# Altium schematic sheet cpu.SchDoc(cpu)
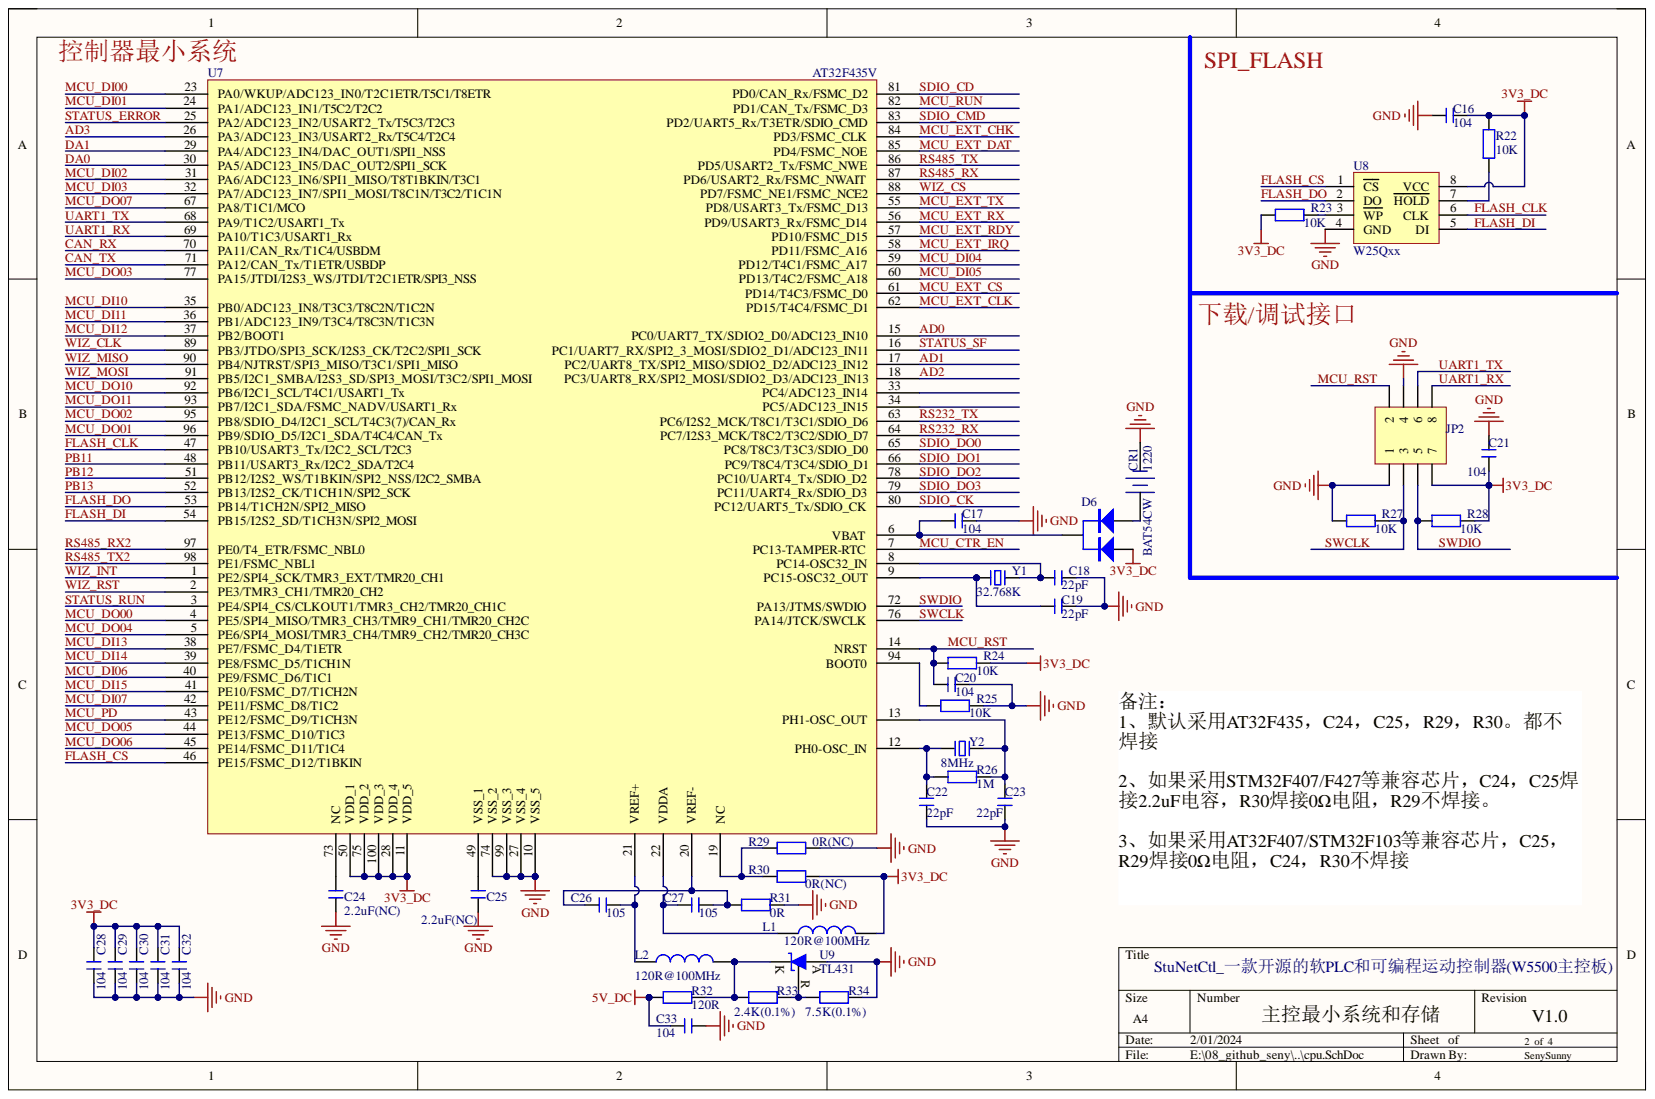
[diagram p0: cpu.SchDoc]

## Parts
C16  104  [C 0603]
C17  104  [C 0603]
C18  22pF  [C 0603]
C19  22pF  [C 0603]
C20  104  [C 0603]
C21  104  [C 0603]
C22  22pF  [C 0603]
C23  22pF  [C 0603]
C24  2.2uF(NC)  [C 0603]
C25  2.2uF(NC)  [C 0603]
C26  105  [C 0603]
C27  105  [C 0603]
C28  104  [C 0603]
C29  104  [C 0603]
C30  104  [C 0603]
C31  104  [C 0603]
C32  104  [C 0603]
C33  104  [C 0603]
CR1  1220  [CR1220_A]
D6  BAT54CW  [SOT23-3N]
JP2  Header 4X2  [HDR-4X2]  (value not drawn)
L1  120R@100MHz  [L 0603]
L2  120R@100MHz  [L 0603]
R22  10K  [R 0603]
R23  10K  [R 0603]
R24  10K  [R 0603]
R25  10K  [R 0603]
R26  1M  [R 0603]
R27  10K  [R 0603]
R28  10K  [R 0603]
R29  0R(NC)  [R 0603]
R30  0R(NC)  [R 0603]
R31  0R  [R 0603]
R32  120R  [R 0603]
R33  2.4K(0.1%)  [R 0603]
R34  7.5K(0.1%)  [R 0603]
U7  AT32F435V  [LQFP100 14X14_N]
U8  W25Qxx  [WSON-8_L6.0-W5.0-P1.27-BL-EP]
U9  TL431  [SOT23-3N]
Y1  32.768K  [XTAL-3215-2P]
Y2  8MHz  [XTAL-5032-2P]

## Nets
3V3_DC: C16.1 C21.2 C28.1 C29.1 C30.1 C31.1 C32.1 D6.1 JP2.7 L1.2 R22.1 R23.1 R24.1 R28.1 R30.2 U7.11(VDD_5) U7.28(VDD_4) U7.50(VDD_1) U7.75(VDD_2) U7.100(VDD_3) U8.8(VCC)
5V_DC: C33.2 R32.2
GND: C16.2 C17.1 C18.1 C19.1 C20.1 C21.1 C22.1 C23.1 C24.2 C25.2 C28.2 C29.2 C30.2 C31.2 C32.2 C33.1 CR1.2 JP2.1 JP2.4 R25.1 R27.2 R29.2 R31.1 R34.1 U7.10(VSS_5) U7.27(VSS_4) U7.74(VSS_2) U7.99(VSS_3) U8.4(GND) U9.3
AD0: U7.15(PC0/UART7_TX/SDIO2_D0/ADC123_IN10)
AD1: U7.17(PC2/UART8_TX/SPI2_MISO/SDIO2_D2/ADC123_IN12)
AD2: U7.18(PC3/UART8_RX/SPI2_MOSI/SDIO2_D3/ADC123_IN13)
AD3: U7.26(PA3/ADC123_IN3/USART2_Rx/T5C4/T2C4)
CAN_RX: U7.70(PA11/CAN_Rx/T1C4/USBDM)
CAN_TX: U7.71(PA12/CAN_Tx/T1ETR/USBDP)
DA0: U7.30(PA5/ADC123_IN5/DAC_OUT2/SPI1_SCK)
DA1: U7.29(PA4/ADC123_IN4/DAC_OUT1/SPI1_NSS)
FLASH_CLK: U7.47(PB10/USART3_Tx/I2C2_SCL/T2C3) U8.6(CLK)
FLASH_CS: U7.46(PE15/FSMC_D12/T1BKIN) U8.1(CS)
FLASH_DI: U7.54(PB15/I2S2_SD/T1CH3N/SPI2_MOSI) U8.5(DI)
FLASH_DO: U7.53(PB14/T1CH2N/SPI2_MISO) U8.2(DO)
MCU_CTR_EN: U7.7(PC13-TAMPER-RTC)
MCU_DI00: U7.23(PA0/WKUP/ADC123_IN0/T2C1ETR/T5C1/T8ETR)
MCU_DI01: U7.24(PA1/ADC123_IN1/T5C2/T2C2)
MCU_DI02: U7.31(PA6/ADC123_IN6/SPI1_MISO/T8T1BKIN/T3C1)
MCU_DI03: U7.32(PA7/ADC123_IN7/SPI1_MOSI/T8C1N/T3C2/T1C1N)
MCU_DI04: U7.59(PD12/T4C1/FSMC_A17)
MCU_DI05: U7.60(PD13/T4C2/FSMC_A18)
MCU_DI06: U7.40(PE9/FSMC_D6/T1C1)
MCU_DI07: U7.42(PE11/FSMC_D8/T1C2)
MCU_DI10: U7.35(PB0/ADC123_IN8/T3C3/T8C2N/T1C2N)
MCU_DI11: U7.36(PB1/ADC123_IN9/T3C4/T8C3N/T1C3N)
MCU_DI12: U7.37(PB2/BOOT1)
MCU_DI13: U7.38(PE7/FSMC_D4/T1ETR)
MCU_DI14: U7.39(PE8/FSMC_D5/T1CH1N)
MCU_DI15: U7.41(PE10/FSMC_D7/T1CH2N)
MCU_DO00: U7.4(PE5/SPI4_MISO/TMR3_CH3/TMR9_CH1/TMR20_CH2C)
MCU_DO01: U7.96(PB9/SDIO_D5/I2C1_SDA/T4C4/CAN_Tx)
MCU_DO02: U7.95(PB8/SDIO_D4/I2C1_SCL/T4C3(7)/CAN_Rx)
MCU_DO03: U7.77(PA15/JTDI/I2S3_WS/JTDI/T2C1ETR/SPI3_NSS)
MCU_DO04: U7.5(PE6/SPI4_MOSI/TMR3_CH4/TMR9_CH2/TMR20_CH3C)
MCU_DO05: U7.44(PE13/FSMC_D10/T1C3)
MCU_DO06: U7.45(PE14/FSMC_D11/T1C4)
MCU_DO07: U7.67(PA8/T1C1/MCO)
MCU_DO10: U7.92(PB6/I2C1_SCL/T4C1/USART1_Tx)
MCU_DO11: U7.93(PB7/I2C1_SDA/FSMC_NADV/USART1_Rx)
MCU_EXT_CHK: U7.84(PD3/FSMC_CLK)
MCU_EXT_CLK: U7.62(PD15/T4C4/FSMC_D1)
MCU_EXT_CS: U7.61(PD14/T4C3/FSMC_D0)
MCU_EXT_DAT: U7.85(PD4/FSMC_NOE)
MCU_EXT_IRQ: U7.58(PD11/FSMC_A16)
MCU_EXT_RDY: U7.57(PD10/FSMC_D15)
MCU_EXT_RX: U7.56(PD9/USART3_Rx/FSMC_D14)
MCU_EXT_TX: U7.55(PD8/USART3_Tx/FSMC_D13)
MCU_PD: U7.43(PE12/FSMC_D9/T1CH3N)
MCU_RST: C20.2 JP2.2 R24.2 U7.14(NRST)
MCU_RUN: U7.82(PD1/CAN_Tx/FSMC_D3)
PB11: U7.48(PB11/USART3_Rx/I2C2_SDA/T2C4)
PB12: U7.51(PB12/I2S2_WS/T1BKIN/SPI2_NSS/I2C2_SMBA)
PB13: U7.52(PB13/I2S2_CK/T1CH1N/SPI2_SCK)
RS232_RX: U7.64(PC7/I2S3_MCK/T8C2/T3C2/SDIO_D7)
RS232_TX: U7.63(PC6/I2S2_MCK/T8C1/T3C1/SDIO_D6)
RS485_RX: U7.87(PD6/USART2_Rx/FSMC_NWAIT)
RS485_RX2: U7.97(PE0/T4_ETR/FSMC_NBL0)
RS485_TX: U7.86(PD5/USART2_Tx/FSMC_NWE)
RS485_TX2: U7.98(PE1/FSMC_NBL1)
SDIO_CD: U7.81(PD0/CAN_Rx/FSMC_D2)
SDIO_CK: U7.80(PC12/UART5_Tx/SDIO_CK)
SDIO_CMD: U7.83(PD2/UART5_Rx/T3ETR/SDIO_CMD)
SDIO_DO0: U7.65(PC8/T8C3/T3C3/SDIO_D0)
SDIO_DO1: U7.66(PC9/T8C4/T3C4/SDIO_D1)
SDIO_DO2: U7.78(PC10/UART4_Tx/SDIO_D2)
SDIO_DO3: U7.79(PC11/UART4_Rx/SDIO_D3)
STATUS_ERROR: U7.25(PA2/ADC123_IN2/USART2_Tx/T5C3/T2C3)
STATUS_RUN: U7.3(PE4/SPI4_CS/CLKOUT1/TMR3_CH2/TMR20_CH1C)
STATUS_SF: U7.16(PC1/UART7_RX/SPI2_3_MOSI/SDIO2_D1/ADC123_IN11)
SWCLK: JP2.3 R27.1 U7.76(PA14/JTCK/SWCLK)
SWDIO: JP2.5 R28.2 U7.72(PA13/JTMS/SWDIO)
UART1_RX: JP2.8 U7.69(PA10/T1C3/USART1_Rx)
UART1_TX: JP2.6 U7.68(PA9/T1C2/USART1_Tx)
WIZ_CLK: U7.89(PB3/JTDO/SPI3_SCK/I2S3_CK/T2C2/SPI1_SCK)
WIZ_CS: U7.88(PD7/FSMC_NE1/FSMC_NCE2)
WIZ_INT: U7.1(PE2/SPI4_SCK/TMR3_EXT/TMR20_CH1)
WIZ_MISO: U7.90(PB4/NJTRST/SPI3_MISO/T3C1/SPI1_MISO)
WIZ_MOSI: U7.91(PB5/I2C1_SMBA/I2S3_SD/SPI3_MOSI/T3C2/SPI1_MOSI)
WIZ_RST: U7.2(PE3/TMR3_CH1/TMR20_CH2)
NetC17_2: C17.2 D6.3 U7.6(VBAT)
NetC18_2: C18.2 U7.8(PC14-OSC32_IN) Y1.2
NetC19_2: C19.2 U7.9(PC15-OSC32_OUT) Y1.1
NetC22_2: C22.2 R26.1 U7.12(PH0-OSC_IN) Y2.1
NetC23_2: C23.2 R26.2 U7.13(PH1-OSC_OUT) Y2.2
NetC24_1: C24.1 U7.73(NC)
NetC25_1: C25.1 U7.49(VSS_1)
NetC26_1: C26.1 L2.1 U7.21(VREF+)
NetC26_2: C26.2 C27.1 R31.2 U7.20(VREF-)
NetC27_2: C27.2 L1.1 U7.22(VDDA)
NetCR1_1: CR1.1 D6.2
NetL2_2: L2.2 R32.1 R33.2 U9.2
NetR22_2: R22.2 U8.7(HOLD)
NetR23_2: R23.2 U8.3(WP)
NetR25_2: R25.2 U7.94(BOOT0)
NetR29_1: R29.1 R30.1 U7.19(NC)
NetR33_1: R33.1 R34.2 U9.1

Not connected: U7 33(PC4/ADC123_IN14) 34(PC5/ADC123_IN15)

## Library data of the parts
C16-C33 = C: 无极性贴片电容; 版权归属: 源创客; BOM名称: 无极性贴片电容; Component Kind: Standard; 学习资源: www.ooocke.com; Library Name: zblib_v1.IntLib
CR1 = CR-3V: 微型电池; 版权归属: 源创客; BOM名称: 微型电池; Component Kind: Standard; 学习资源: www.ooocke.com; Library Name: zblib_v1.IntLib
D6 = BAT54CW: 肖特基二极管; 属性: 40V/1A; 版权归属: 源创客; BOM名称: 肖特基二极管; Component Kind: Standard; 学习资源: www.ooocke.com; Library Name: zblib_v1.IntLib
JP2 = Header 4X2: 4*2P接插件; 版权归属: 源创客; BOM名称: 4*2P接插件; Component Kind: Standard; 学习资源: www.ooocke.com; Library Name: zblib_v1.IntLib
L1 L2 = L: 小功率贴片电感; 版权归属: 源创客; BOM名称: 小功率贴片电感; Component Kind: Standard; 学习资源: www.ooocke.com; Library Name: zblib_v1.IntLib
R22-R34 = R: 贴片电阻; 版权归属: 源创客; BOM名称: 贴片电阻; Component Kind: Standard; 学习资源: www.ooocke.com; Library Name: zblib_v1.IntLib
U7 = AT32F435V: ARTERY Ｍ4处理器，主频288Mhz,100引脚; BOM名称: 32位STM单片机100引脚; 版权归属: 源创客; Component Kind: Standard; 学习资源: www.ooocke.com; Library Name: zblib_v1.IntLib
U8 = W25Qxx: 外置Flash; 版权归属: 源创客; BOM名称: 外置Flash; Component Kind: Standard; 学习资源: www.ooocke.com; Library Name: zblib_v1.IntLib
U9 = TL431_SMD: 可调基准稳压芯片; 版权归属: 源创客; BOM名称: 可调基准稳压芯片; Component Kind: Standard; 学习资源: www.ooocke.com; Library Name: zblib_v1.IntLib
Y1 Y2 = XTAL-2: 2脚无源晶振; BOM名称: 2脚晶振; 版权归属: 源创客; Component Kind: Standard; 学习资源: www.ooocke.com; Library Name: zblib_v1.IntLib
